# Altium schematic sheet SM1.SchDoc(SM2)
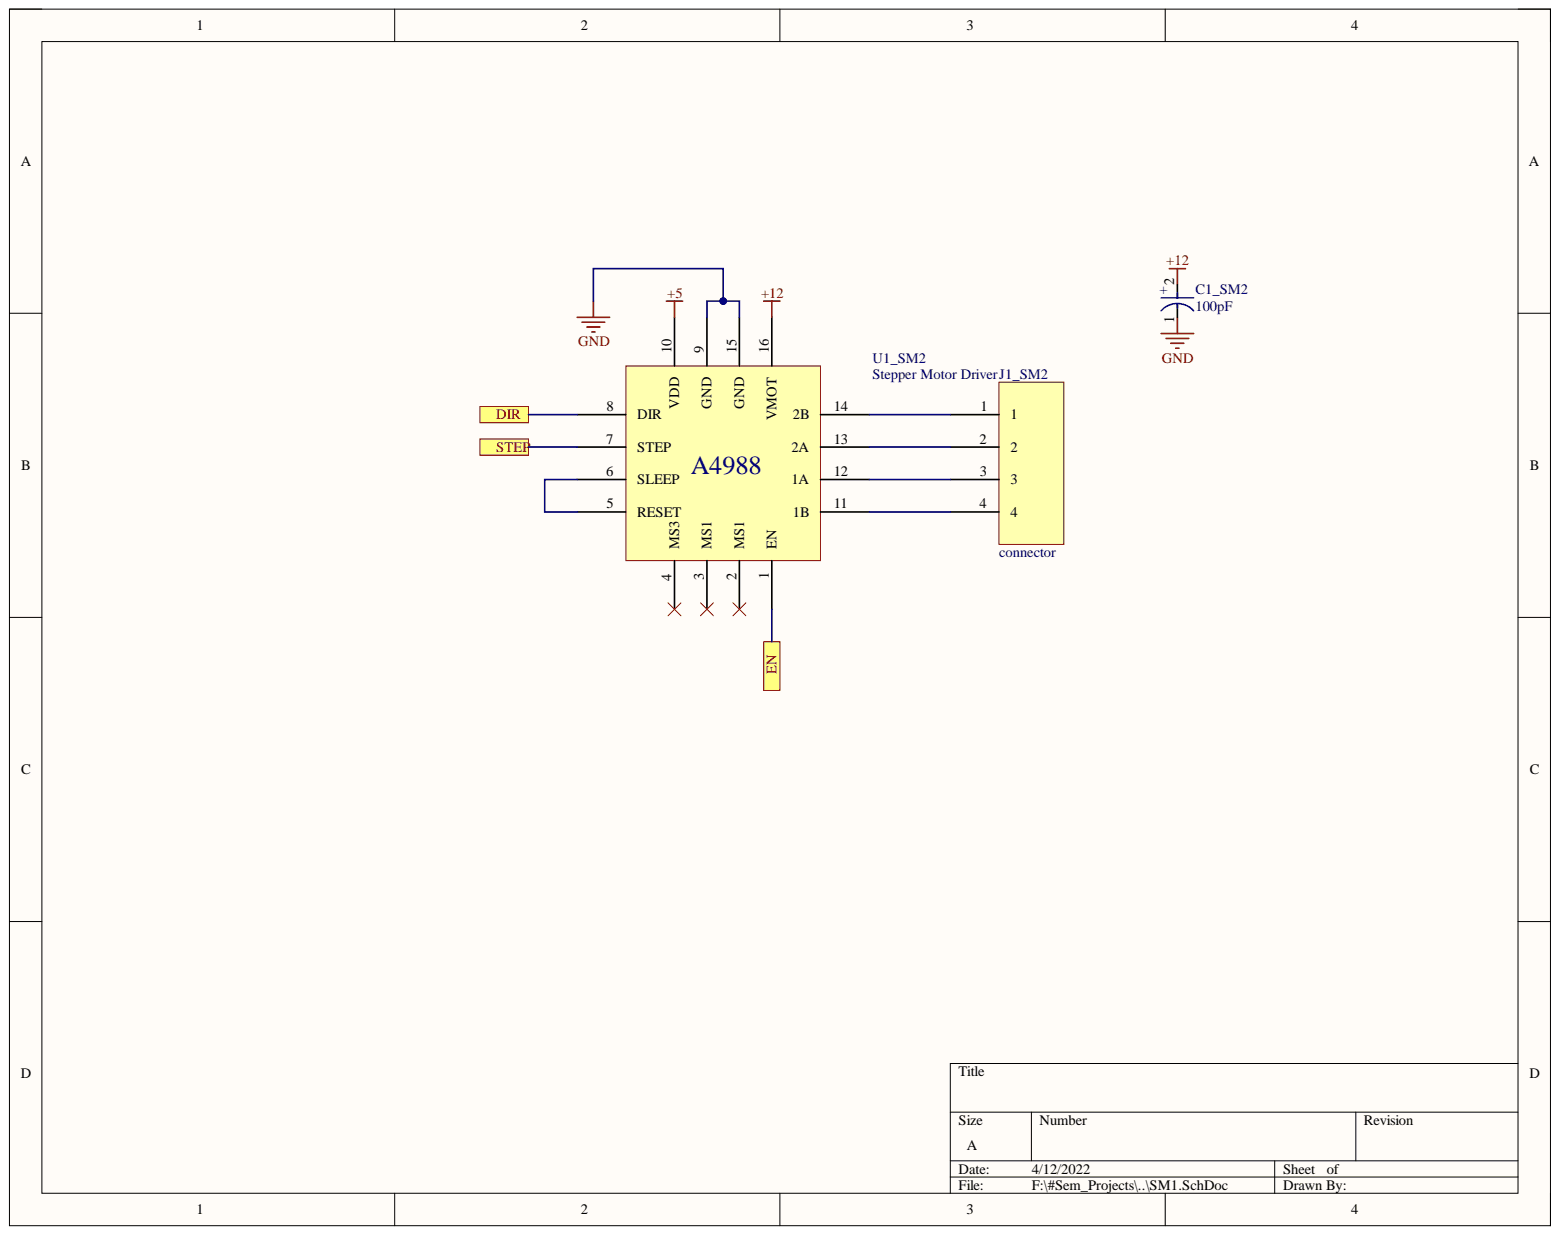
[diagram p0: SM1.SchDoc]

## Parts
C1_SM2  100pF  [FP-SC-MFG]
J1_SM2  connector  [JST_4P]
U1_SM2  Stepper Motor Driver  [A4988]

## Ports
DIR_SM2, EN_SM2, STEP_SM2

## Nets
+5: U1_SM2.10(VDD)
+12: C1_SM2.2 U1_SM2.16(VMOT)
GND: C1_SM2.1 U1_SM2.9(GND) U1_SM2.15(GND)
NetJ1_SM2_1: J1_SM2.1 U1_SM2.14(2B)
NetJ1_SM2_2: J1_SM2.2 U1_SM2.13(2A)
NetJ1_SM2_3: J1_SM2.3 U1_SM2.12(1A)
NetJ1_SM2_4: J1_SM2.4 U1_SM2.11(1B)
NetU1_SM2_1: U1_SM2.1(EN) ; port EN_SM2
NetU1_SM2_2: U1_SM2.2(MS1)
NetU1_SM2_3: U1_SM2.3(MS1)
NetU1_SM2_4: U1_SM2.4(MS3)
NetU1_SM2_5: U1_SM2.5(RESET) U1_SM2.6(SLEEP)
NetU1_SM2_7: U1_SM2.7(STEP) ; port STEP_SM2
NetU1_SM2_8: U1_SM2.8(DIR) ; port DIR_SM2

## Library data of the parts
C1_SM2 = CMP-05427-000087-1: CAP ALUM 10UF 20% 35V SMD; Lead Length: 2.2mm; Leakage Current: 3uA; Life (Hours): 2000Hour; Ripple Current: 30mA; Capacitance: 10uF; Case/Package: Radial; Component Kind: Standard; Construction: Cylindrical; Contact Plating: Tin; Diameter: 5mm; Height: 5.4mm; Height - Seated (Max): 0.213inch; Lead Pitch: 1.5mm; Length: 5.5mm; Library Name: Altium Content Vault; Max Operating Temperature: 85°C; Min Operating Temperature: -40°C; Mount: Surface Mount; Number of Pins: 2; Package Quantity: 1; Packaging: Tape and Reel; Radiation Hardening: No; REACH SVHC: No SVHC; Ripple Current (AC): 30mA; RoHS Compliant: Yes; Series: S; Termination: SMD/SMT; Tolerance: 20%; Voltage: 35V; Voltage Rating: 35V; Voltage Rating (DC): 35V; Width: 5.3mm
J1_SM2 = JST_4P: Component Kind: Standard; Library Name: Schlib.SchLib
U1_SM2 = A4988: Component Kind: Standard; Library Name: Schlib.SchLib
